# Altium schematic sheet p10-1_Gig-ENET0.SchDoc
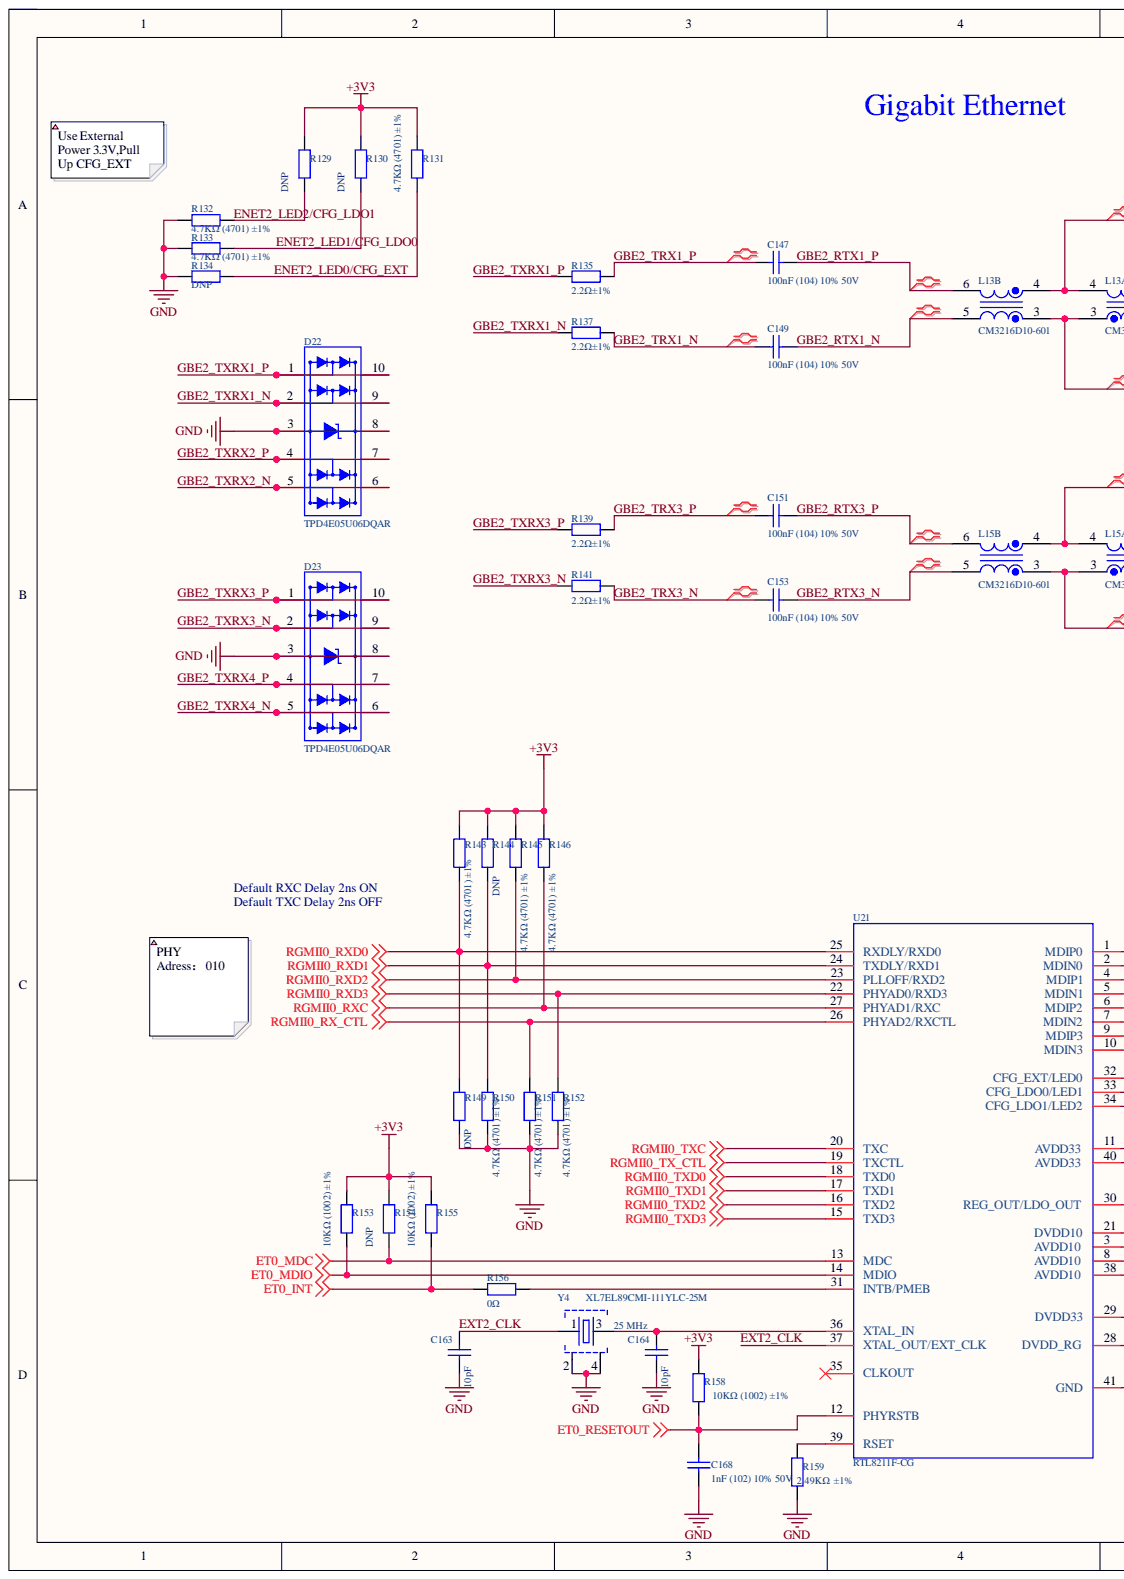
[diagram p0: p10-1_Gig-ENET0.SchDoc, left]
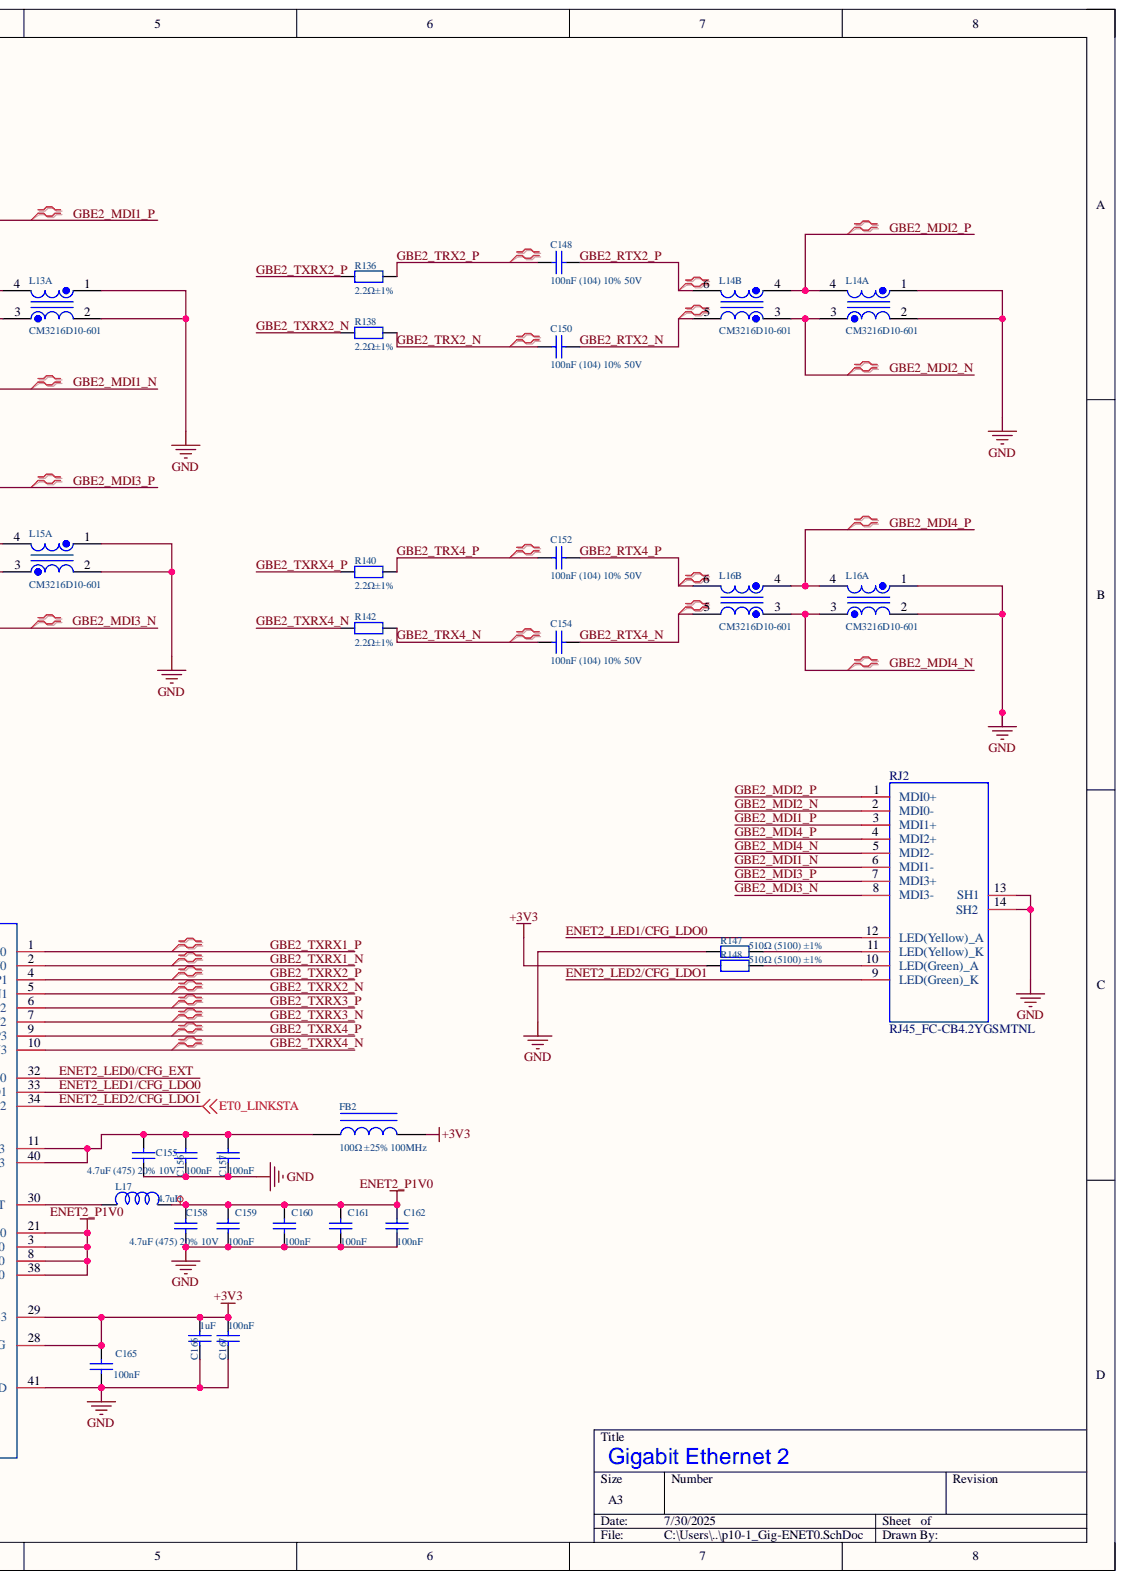
[diagram p1: p10-1_Gig-ENET0.SchDoc, right]

## Parts
C147  100nF (104) 10% 50V  [C0402]
C148  100nF (104) 10% 50V  [C0402]
C149  100nF (104) 10% 50V  [C0402]
C150  100nF (104) 10% 50V  [C0402]
C151  100nF (104) 10% 50V  [C0402]
C152  100nF (104) 10% 50V  [C0402]
C153  100nF (104) 10% 50V  [C0402]
C154  100nF (104) 10% 50V  [C0402]
C155  4.7uF (475) 20% 10V  [C0402]
C156  Capacitance: 100nF  [C0402]  (hidden comment: 100 nf (0.1uF) 16V)
C157  Capacitance: 100nF  [C0402]  (hidden comment: 100 nf (0.1uF) 16V)
C158  4.7uF (475) 20% 10V  [C0402]
C159  Capacitance: 100nF  [C0402]  (hidden comment: 100 nf (0.1uF) 16V)
C160  Capacitance: 100nF  [C0402]  (hidden comment: 100 nf (0.1uF) 16V)
C161  Capacitance: 100nF  [C0402]  (hidden comment: 100 nf (0.1uF) 16V)
C162  Capacitance: 100nF  [C0402]  (hidden comment: 100 nf (0.1uF) 16V)
C163  Capacitance: 10pF  [C0402]  (hidden comment: 10pF 50V)
C164  Capacitance: 10pF  [C0402]  (hidden comment: 10pF 50V)
C165  Capacitance: 100nF  [C0402]  (hidden comment: 100 nf (0.1uF) 16V)
C166  Capacitance: 1uF  [C0402]  (hidden comment: 1uF 16V)
C167  Capacitance: 100nF  [C0402]  (hidden comment: 100 nf (0.1uF) 16V)
C168  1nF (102) 10% 50V  [C0402]
D22  TPD4E05U06DQAR  [USON-10_L2.5-W1.0-P0.50-BL]
D23  TPD4E05U06DQAR  [USON-10_L2.5-W1.0-P0.50-BL]
FB2  100Ω ±25% 100MHz  [0805_L]
L13  CM3216D10-601  [FILTER-SMD_6P-L3.4-W1.6_SFI1206-601R201]  (parts A,B)
L14  CM3216D10-601  [FILTER-SMD_6P-L3.4-W1.6_SFI1206-601R201]  (parts A,B)
L15  CM3216D10-601  [FILTER-SMD_6P-L3.4-W1.6_SFI1206-601R201]  (parts A,B)
L16  CM3216D10-601  [FILTER-SMD_6P-L3.4-W1.6_SFI1206-601R201]  (parts A,B)
L17  Inductance: 4.7uH  [FB_0805]  (hidden comment: IND-100023-01)
R129  DNP  [R0402]
R130  DNP  [R0402]
R131  4.7KΩ (4701) ±1%  [R0402]
R132  4.7KΩ (4701) ±1%  [R0402]
R133  4.7KΩ (4701) ±1%  [R0402]
R134  DNP  [R0402]
R135  2.2Ω±1%  [R0201]
R136  2.2Ω±1%  [R0201]
R137  2.2Ω±1%  [R0201]
R138  2.2Ω±1%  [R0201]
R139  2.2Ω±1%  [R0201]
R140  2.2Ω±1%  [R0201]
R141  2.2Ω±1%  [R0201]
R142  2.2Ω±1%  [R0201]
R143  4.7KΩ (4701) ±1%  [R0402]
R144  DNP  [R0402]
R145  4.7KΩ (4701) ±1%  [R0402]
R146  4.7KΩ (4701) ±1%  [R0402]
R147  510Ω (5100) ±1%  [R0402]
R148  510Ω (5100) ±1%  [R0402]
R149  DNP  [R0402]
R150  4.7KΩ (4701) ±1%  [R0402]
R151  4.7KΩ (4701) ±1%  [R0402]
R152  4.7KΩ (4701) ±1%  [R0402]
R153  10KΩ (1002) ±1%  [R0402]
R154  DNP  [R0402]
R155  10KΩ (1002) ±1%  [R0402]
R156  0Ω  [R0402]
R158  10KΩ (1002) ±1%  [R0402]
R159  2.49KΩ  ±1%  [R0402]
RJ2  RJ45_FC-CB4.2YGSMTNL  [RJ45-TH_FC-CB4.2YGSMTNL]
U21  RTL8211F-CG  [WQFN-40_L5.0-W5.0-P0.4-BL-EP]
Y4  XL7EL89CMI-111YLC-25M  [CYR-2016]

## Nets
+3V3: C165.1 C166.1 C167.1 FB2.2 R129.2 R130.2 R131.2 R143.2 R144.2 R145.2 R146.2 R148.1 R153.2 R154.2 R155.2 R158.2 U21.28(DVDD_RG) U21.29(DVDD33)
ENET2_P1V0: C158.2 C159.2 C160.2 C161.2 C162.2 L17.2 U21.3(AVDD10) U21.8(AVDD10) U21.21(DVDD10) U21.38(AVDD10)
ET0_INT: R155.1 R156.1
ET0_MDC: R154.1 U21.13(MDC)
ET0_MDIO: R153.1 U21.14(MDIO)
ET0_RESETOUT: C168.2 R158.1 U21.12(PHYRSTB)
GND: C155.2 C156.1 C157.2 C158.1 C159.1 C160.1 C161.1 C162.1 C163.1 C164.1 C165.2 C166.2 C167.2 C168.1 D22.3 D22.8 D23.3 D23.8 L13.1 L13.2 L14.1 L14.2 L15.1 L15.2 L16.1 L16.2 R132.1 R133.1 R134.1 R147.1 R149.1 R150.1 R151.1 R152.1 R159.1 RJ2.13(SH1) RJ2.14(SH2) U21.41(GND) Y4.2 Y4.4
RGMII0_RX_CTL: R151.2 U21.26(PHYAD2/RXCTL)
RGMII0_RXC: R146.1 U21.27(PHYAD1/RXC)
RGMII0_RXD0: R143.1 R149.2 U21.25(RXDLY/RXD0)
RGMII0_RXD1: R144.1 R150.2 U21.24(TXDLY/RXD1)
RGMII0_RXD2: R145.1 U21.23(PLLOFF/RXD2)
RGMII0_RXD3: R152.2 U21.22(PHYAD0/RXD3)
RGMII0_TX_CTL: U21.19(TXCTL)
RGMII0_TXC: U21.20(TXC)
RGMII0_TXD0: U21.18(TXD0)
RGMII0_TXD1: U21.17(TXD1)
RGMII0_TXD2: U21.16(TXD2)
RGMII0_TXD3: U21.15(TXD3)
ENET2_LED0/CFG_EXT: R131.1 R134.2 U21.32(CFG_EXT/LED0)
ENET2_LED1/CFG_LDO0: R130.1 R133.2 RJ2.12(LED(Yellow)_A) U21.33(CFG_LDO0/LED1)
ENET2_LED2/CFG_LDO1: R129.1 R132.2 RJ2.9(LED(Green)_K) U21.34(CFG_LDO1/LED2)
EXT2_CLK: C163.2 U21.37(XTAL_OUT/EXT_CLK) Y4.1
GBE2_MDI1_N: L13.3 RJ2.6(MDI1-)
GBE2_MDI1_P: L13.4 RJ2.3(MDI1+)
GBE2_MDI2_N: L14.3 RJ2.2(MDI0-)
GBE2_MDI2_P: L14.4 RJ2.1(MDI0+)
GBE2_MDI3_N: L15.3 RJ2.8(MDI3-)
GBE2_MDI3_P: L15.4 RJ2.7(MDI3+)
GBE2_MDI4_N: L16.3 RJ2.5(MDI2-)
GBE2_MDI4_P: L16.4 RJ2.4(MDI2+)
GBE2_RTX1_N: C149.2 L13.5
GBE2_RTX1_P: C147.2 L13.6
GBE2_RTX2_N: C150.2 L14.5
GBE2_RTX2_P: C148.2 L14.6
GBE2_RTX3_N: C153.2 L15.5
GBE2_RTX3_P: C151.2 L15.6
GBE2_RTX4_N: C154.2 L16.5
GBE2_RTX4_P: C152.2 L16.6
GBE2_TRX1_N: C149.1 R137.2
GBE2_TRX1_P: C147.1 R135.2
GBE2_TRX2_N: C150.1 R138.2
GBE2_TRX2_P: C148.1 R136.2
GBE2_TRX3_N: C153.1 R141.2
GBE2_TRX3_P: C151.1 R139.2
GBE2_TRX4_N: C154.1 R142.2
GBE2_TRX4_P: C152.1 R140.2
GBE2_TXRX1_N: D22.2 D22.9 R137.1 U21.2(MDIN0)
GBE2_TXRX1_P: D22.1 D22.10 R135.1 U21.1(MDIP0)
GBE2_TXRX2_N: D22.5 D22.6 R138.1 U21.5(MDIN1)
GBE2_TXRX2_P: D22.4 D22.7 R136.1 U21.4(MDIP1)
GBE2_TXRX3_N: D23.2 D23.9 R141.1 U21.7(MDIN2)
GBE2_TXRX3_P: D23.1 D23.10 R139.1 U21.6(MDIP2)
GBE2_TXRX4_N: D23.5 D23.6 R142.1 U21.10(MDIN3)
GBE2_TXRX4_P: D23.4 D23.7 R140.1 U21.9(MDIP3)
NetC155_1: C155.1 C156.2 C157.1 FB2.1 U21.11(AVDD33) U21.40(AVDD33)
NetC164_2: C164.2 U21.36(XTAL_IN) Y4.3
NetL17_1: L17.1 U21.30(REG_OUT/LDO_OUT)
NetR147_2: R147.2 RJ2.11(LED(Yellow)_K)
NetR148_2: R148.2 RJ2.10(LED(Green)_A)
NetR156_2: R156.2 U21.31(INTB/PMEB)
NetR159_2: R159.2 U21.39(RSET)

Not connected: U21 35(CLKOUT)

## Library data of the parts
C147-C154 = 0402 100nF (104) 10% 50V: 贴片电容; Component Kind: Standard; ComponentLink1Description: 供应商链接; ComponentLink1URL: https://item.szlcsc.com/111473.html; Library Name: Cap.SchLib; Package: 0402; Supplier: LC; SuppliersPartNumber: C1525
C155 C158 = 0402 4.7uF (475) 20% 10V: 贴片电容; Component Kind: Standard; ComponentLink1Description: 供应商链接; ComponentLink1URL: http://www.szlcsc.com/product/details_24469.html; Library Name: Cap.SchLib; Package: 0402; Supplier: LC; SuppliersPartNumber: C23733
C156 C157 C159-C162 C165 C167 = CAP-000030-01: Ceramic Chip Capacitor 0402 100 nf (0.1uF) 16V; Legacy Part Number: 151-10004022; Manufacturer: Yageo; Manufacturer Part Number 1: CC0402KRX7R8BB104; Dialectric: X7R; Notes: ANY EQUIVALENT; Tolerance: 10%; Component Kind: Standard; Library Name: ra8p1_v0.1.SCHLIB; Manufacturer 1: Yageo; Manufacturer 2: Kemet; Manufacturer 3: AVX; Manufacturer Part Number 2: C0402C104K4RACTU; Manufacturer Part Number 3: 0402YC104KAT2A; Mfg: Yageo; Mfg P/N: CC0402KRX7R8BB104; Mfg Part Number: CC0402KRX7R8BB104; Package: 0402; PN: CC0402KRX7R8BB104; Supplier 1: Arrow Electronics; Supplier 2: Digi-Key; Supplier 3: Newark; Supplier 4: Mouser; Supplier Part Number 1: CC0402KRX7R8BB104; Supplier Part Number 2: 311-2077-1-ND; Supplier Part Number 3: 40AJ6370; Supplier Part Number 4: 603-CC402KRX7R8BB104; Voltage: 16V
C163 C164 = CAP-100118-01: Ceramic Chip Capacitor 0402 10pF 50V; Legacy Part Number: 151-10005153; Manufacturer: Yageo; Manufacturer Part Number 1: CC0402JRNPO9BN100; Dialectric: COG/NPO; Notes: ANY EQUIVALENT; Tolerance: 5%; Component Kind: Standard; Library Name: Capacitors.IntLib; Manufacturer 1: Yageo; Mfg: Yageo; Mfg P/N: CC0402JRNPO9BN100; Mfg Part Number: CC0402JRNPO9BN100; Package: 0402; PN: CC0402JRNPO9BN100; Supplier 1: Digi-Key; Supplier Part Number 1: 311-1014-1-ND; Voltage: 50V
C166 = CAP-000063-01: Ceramic Chip Capacitor 0402 1uF 16V; Manufacturer: Murata; Manufacturer Part Number 1: GRM155R61E105MA12D; Dialectric: X5R; Notes: ANY EQUIVALENT; Tolerance: 10%; Value: 1u; Component Kind: Standard; Library Name: ra8p1_v0.1.SCHLIB; Manufacturer 1: Murata; Manufacturer 2: Samsung; Manufacturer Part Number 2: CL05A105KO5NNNC; Mfg: Murata; Mfg P/N: GRM155R61E105MA12D; Mfg Part Number: GRM155R61E105MA12D; Package: 0402; PN: GRM155R61E105MA12D; Simulation: C0402_X5R_1UF; Supplier 1: Digi-Key; Supplier 2: Digi-Key; Supplier 3: Digi-Key; Supplier Part Number 1: 1276-1067-1-ND; Supplier Part Number 2: 1276-1067-6-ND; Supplier Part Number 3: 490-10018-1-ND; Voltage: 16V
C168 = 0402 1nF (102) 10% 50V: 贴片电容; Component Kind: Standard; ComponentLink1Description: 供应商链接; ComponentLink1URL: http://www.szlcsc.com/product/details_1875.html; Library Name: Cap.SchLib; Package: 0402; Supplier: LC; SuppliersPartNumber: C1523
D22 D23 = TPD4E05U06DQAR: Manufacture: SOCAY(硕凯); Part Name: ESD; Part Number: C040504013981; DSC1: 双向; DSC2: Vdc=5V; DSC3: Vbr=8V; DSC4: Vc=20V; DSC5: Cj=0.4pF; ERP Number: 1010060056; ID: 8; PCB Footprint: DFN2510-10L; Solder: 推荐; Component Kind: Standard; Date: 05132005; Library Name: ra8p1_v0.1.SCHLIB; Price: 0.15
FB2 = 0805 100Ω 25% 100MHz: 贴片磁珠; Component Kind: Standard; ComponentLink1Description: 供应商链接; ComponentLink1URL: http://www.szlcsc.com/product/details_1367.html; Library Name: IUD.SchLib; Package: 0805; Supplier: LC; SuppliersPartNumber: C1015
L13-L16 = CM3216D10-601: Cap+CMC Solution, Inductance=55uH(100Khz), 0.2A,125V; Component Kind: Standard; Library Name: ra8p1_v0.2.SCHLIB
L17 = IND-100023-01: Chip Inductor, 4.7uH Shielded Multilayer, 700mA 260mohm 0805 20%; Manufacturer: TDK; DisplayName: MLP2012S4R7MT0S1; Component Kind: Standard; Current: 700mA; DC Resistance: 260mohm; Library Name: Misc.IntLib; Manufacturer 1: TDK; Manufacturer Part Number 1: TDK; Mfg: TDK; Mfg Part Number: TDK; Package: 0805; PN: MLP2012S4R7MT0S1; Supplier 1: Arrow Electronics; Supplier 2: Digi-Key; Supplier 3: Mouser; Supplier 4: Digi-Key; Supplier 5: Mouser; Supplier Part Number 1: MLP2012S4R7MT0S1; Supplier Part Number 2: 445-6366-1-ND; Supplier Part Number 3: 810-MLP2012S4R7MT0S1; Supplier Part Number 4: 553-BKPB002012104R7MA2CT-ND; Supplier Part Number 5: 603-BKPB2012104R7MA2; Tolerance: 20%
R129 R130 R134 R144 R149 = 0402 4.7KΩ (4701) 1%: 贴片电阻,4.7K; Component Kind: Standard; ComponentLink1Description: 供应商链接; ComponentLink1URL: http://www.szlcsc.com/product/details_26643.html; Library Name: RES.SchLib; Package: 0402; Supplier: LC; SuppliersPartNumber: C25900
R131-R133 R143 R145 R146 R150-R152 = 0402 4.7KΩ (4701) 1%: 贴片电阻; Component Kind: Standard; ComponentLink1Description: 供应商链接; ComponentLink1URL: http://www.szlcsc.com/product/details_26643.html; Library Name: RES.SchLib; Package: 0402; Supplier: LC; SuppliersPartNumber: C25900
R135-R142 = 0402 2.2Ω 1%: 贴片电阻; Component Kind: Standard; ComponentLink1Description: 供应商链接; ComponentLink1URL: https://item.szlcsc.com/25844.html?fromZone=s_s__%25222.2r%2522&spm=sc.gb.xh1.zy.n___sc.gb.hd.ss&lcsc_vid=E1YIU1FRQVIMBVNRRVhcBAYDQwJbUQICQFgLBVMDElIxVlNSQlJZX1dWRVBcUjsOAxUeFF5JWBYZEEoVDQ0NFAdIFA4DSA%3D%3D; Library Name: RES.SchLib; Package: 0402; Supplier: LC; SuppliersPartNumber: C25879
R147 R148 = 0402 510Ω (5100) 1%: 贴片电阻; Component Kind: Standard; ComponentLink1Description: 供应商链接; ComponentLink1URL: http://www.szlcsc.com/product/details_25866.html; Library Name: RES.SchLib; Package: 0402; Supplier: LC; SuppliersPartNumber: C25123
R153-R155 R158 = 0402 10KΩ (1002) 1%: 贴片电阻，10K; Component Kind: Standard; ComponentLink1Description: 供应商链接; ComponentLink1URL: http://www.szlcsc.com/product/details_26487.html; Library Name: RES.SchLib; Package: 0402; Supplier: LC; SuppliersPartNumber: C25744
R156 = 0402 0Ω (0R0) 1%: 贴片电阻; Component Kind: Standard; ComponentLink1Description: 供应商链接; ComponentLink1URL: http://www.szlcsc.com/product/details_17853.html; Library Name: RES.SchLib; Package: 0402; Supplier: LC; SuppliersPartNumber: C17168
R159 = 0402 2.49KΩ 1%: 贴片电阻; Component Kind: Standard; ComponentLink1Description: 供应商链接; ComponentLink1URL: http://www.szlcsc.com/product/details_26625.html; Library Name: ra8p1_v0.1.SCHLIB; Package: 0402; Supplier: LC; SuppliersPartNumber: C25882
RJ2 = RJ45_FC-CB4.2YGSMTNL: Connector_RJ45, single port, with LEDS,SMD; Internal_Part_Number: MCD-00717; Manufacturer: DONGGUAN KEYOUDA ELECTRONIC TECHNOLOGY; Part_Preference: Recommended; Component_Country_Of_Origin: Unknown; ID: 3029; ShowFields: YES; Component Kind: Standard; ComponentLink1URL: http://tunmcdsvn.tun.st.com/Component_Documentation_Library/Trunk/Connector/DONGGUAN_KEYOUDA_ELECTRONIC_TECHNOLOGY_KRJ-CB4.2GYZNL/; Library Name: ra8p1_v0.2.SCHLIB; Manufacturer_Part_Number: RJ45_FC-CB4.2YGSMTNL; Order_Code_Digikey: N/A; Order_Code_Farnell: N/A; Order_Code_RS: N/A; Part_Type: Connector; Status: Released; Value: RJ45_FC-CB4.2YGSMTNL
U21 = RTL8211F-CG: RGMII; Manufacturer: REALTEK(瑞昱); Add into BOM: yes; Number of Channels: 4; Supply Voltage: 1.8V；2.5V；3.3V；1.5V; Application Function: -; Component Kind: Standard; Convert to PCB: yes; Datasheet: https://atta.szlcsc.com/upload/public/pdf/source/20190902/C187932_1913F3711F05FE1324DF2946D70D648D.pdf; Device: RTL8211F-CG; Interface: RGMII; JLCPCB Part Class: Extended Part; LCSC Part Name: 集成10/100/1000M以太网精密收发器; Library Name: ra8p1_v0.1.SCHLIB; Manufacturer Part: RTL8211F-CG; PCB3D: WQFN-40_L5.0-W5.0-P0.4-BL-EP; Supplier: LCSC; Supplier Footprint: WQFN-40-EP(5x5); Supplier Part: C187932; Symbol: RTL8211F-CG
Y4 = XTL-100008-01: 25MHz ±10ppm 10pF，100ohom ESR  XL7EL89CMI-111YLC-25M; Manufacturer: ECS; Manufacturer Part Number 1: ECS-250-10-37B-CTN-TR; ESR: 100 ohm; Freq Stability: +/- 20ppm; Freq Tolerance: +/- 10ppm; Load Capacitance: 10pF; Notes: MUST USE SPECIFIC; Component Kind: Standard; ComponentLink1Description: New link; ComponentLink1URL: https://item.szlcsc.com/23919117.html?fromZone=l_b__%2522brand%2522&spm=sc.bidn.xh6.zy.n___sc.gbn.xh1.zy.p&lcsc_vid=FlRcAQUARlUMU1NWEQALBQBeQllfVQcHQVAMX1FeFAcxVlNSTlBXXlFTQFBfUDsOAxUeFF5JWAgaAglIBBsCBBcFWQIBCks%3D; Frequency: 25 MHz; Library Name: Misc.IntLib; Manufacturer 1: ECS; Mfg: ECS; Mfg Part Number: ECS-250-10-37B-CTN-TR; PN: ECS-250-10-37B-CTN-TR; Supplier 1: Avnet; Supplier 2: Mouser; Supplier 3: Digi-Key; Supplier Part Number 1: ECS-250-10-37B-CTN-TR; Supplier Part Number 2: 520-250-10-37B-CTNT; Supplier Part Number 3: XC3065CT-ND
